# Altium schematic sheet SoM.SchDoc(SoM)
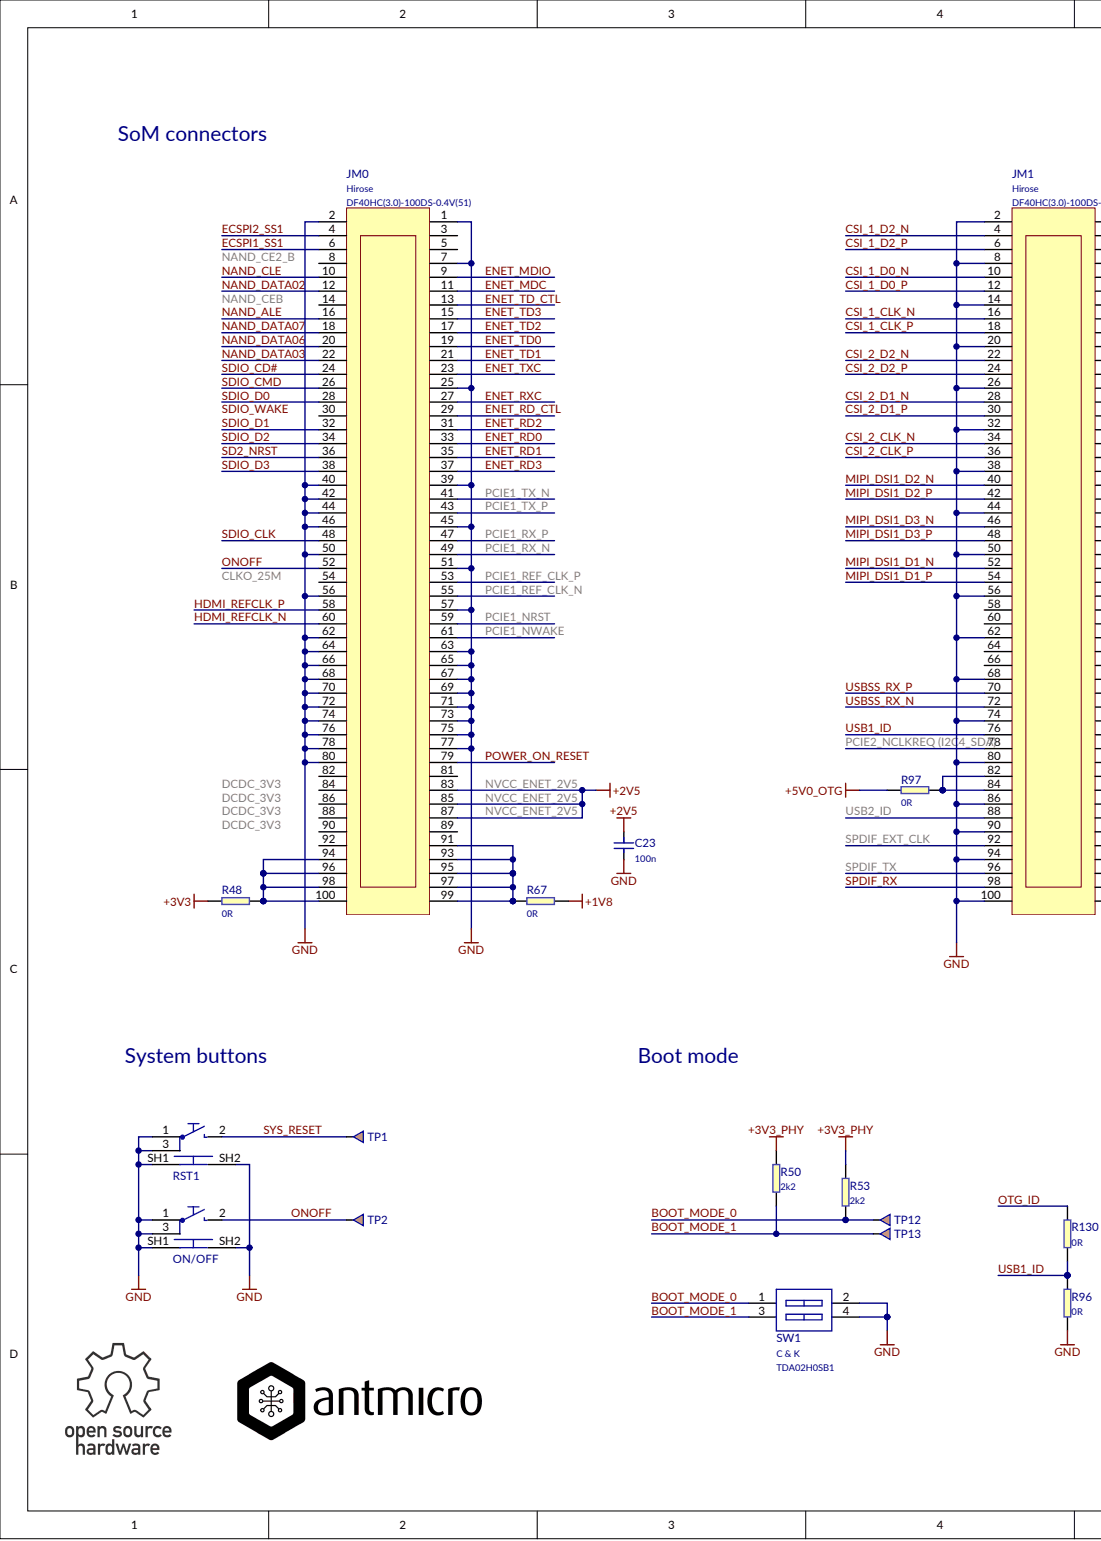
[diagram p0: SoM.SchDoc, left]
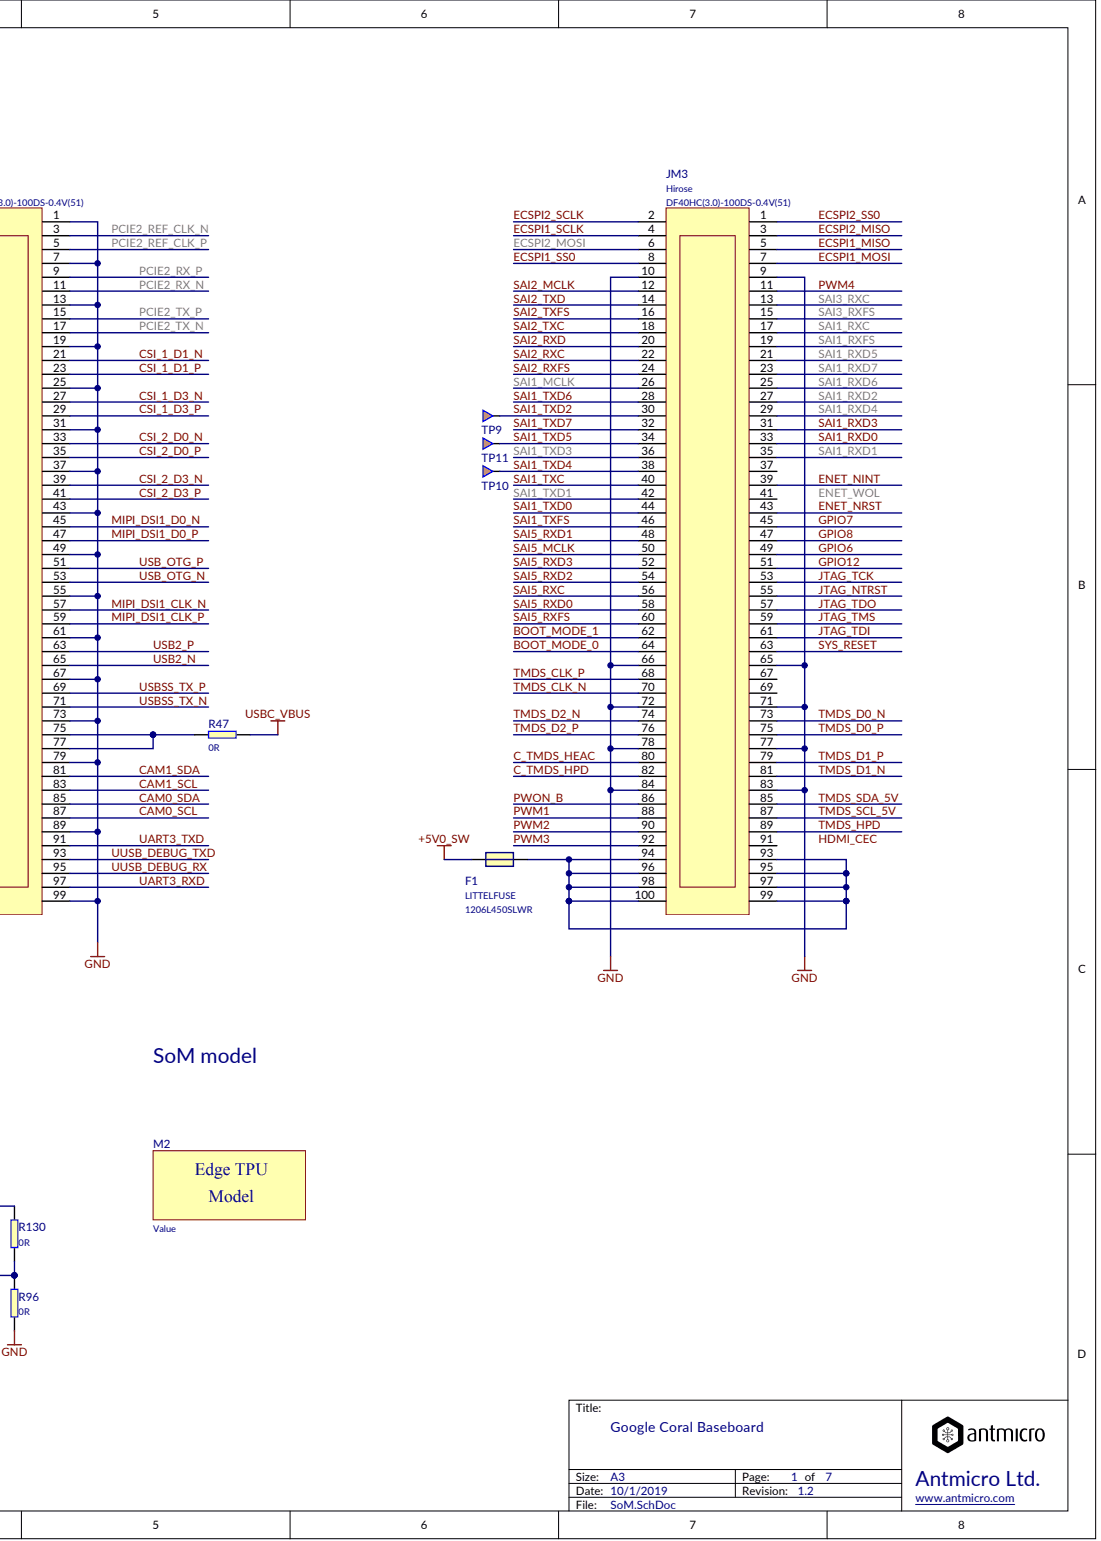
[diagram p1: SoM.SchDoc, right]

## Parts
C23  100n
F1  value 1206L450SLWR  Manufacturer: LITTELFUSE  (hidden comment: Value)
JM0  Manufacturer: Hirose  (hidden comment: DF40HC(3.0)-100DS-0.4V(51))
JM1  Manufacturer: Hirose  (hidden comment: DF40HC(3.0)-100DS-0.4V(51))
JM3  Manufacturer: Hirose  (hidden comment: DF40HC(3.0)-100DS-0.4V(51))
M2  =Value
ON/OFF  TL3340AF160QG  (value not drawn)
R47  0R
R48  0R
R50  2k2
R53  2k2
R67  0R
R96  0R
R97  0R
R130  0R
RST1  TL3340AF160QG  (value not drawn)
SW1  Manufacturer: C & K  (hidden comment: TDA02H0SB1)
TP1  Testpad  (value not drawn)
TP2  Testpad  (value not drawn)
TP9  Testpad  (value not drawn)
TP10  Testpad  (value not drawn)
TP11  Testpad  (value not drawn)
TP12  Testpad  (value not drawn)
TP13  Testpad  (value not drawn)

## Nets
+1V8: R67.2
+3V3: R48.2
+3V3_PHY: R50.2 R53.2
+5V0_OTG: R97.2
+5V0_SW: F1.1
GND: C23.2 JM0.1 JM0.2 JM0.7 JM0.25 JM0.39 JM0.40 JM0.42 JM0.44 JM0.45 JM0.46 JM0.50 JM0.51 JM0.56 JM0.57 JM0.62 JM0.63 JM0.64 JM0.65 JM0.66 JM0.67 JM0.68 JM0.69 JM0.70 JM0.71 JM0.72 JM0.73 JM0.74 JM0.75 JM0.76 JM0.77 JM0.78 JM0.80 JM1.1 JM1.2 JM1.7 JM1.8 JM1.13 JM1.14 JM1.19 JM1.20 JM1.25 JM1.26 JM1.31 JM1.32 JM1.37 JM1.38 JM1.43 JM1.44 JM1.49 JM1.50 JM1.55 JM1.56 JM1.61 JM1.62 JM1.67 JM1.68 JM1.73 JM1.74 JM1.79 JM1.80 JM1.86 JM1.89 JM1.90 JM1.94 JM1.99 JM1.100 JM3.9 JM3.10 JM3.65 JM3.66 JM3.71 JM3.72 JM3.77 JM3.78 JM3.83 JM3.84 ON/OFF.1 ON/OFF.3 ON/OFF.SH1 ON/OFF.SH2 R96.1 RST1.1 RST1.3 RST1.SH1 RST1.SH2 SW1.2 SW1.4
USBC_VBUS: R47.1
BOOT_MODE_0: JM3.64 R53.1 SW1.1 TP12.1
BOOT_MODE_1: JM3.62 R50.1 SW1.3 TP13.1
C_TMDS_HEAC: JM3.80
C_TMDS_HPD: JM3.82
CAM0_SCL: JM1.87
CAM0_SDA: JM1.85
CAM1_SCL: JM1.83
CAM1_SDA: JM1.81
CSI_1_CLK_N: JM1.16
CSI_1_CLK_P: JM1.18
CSI_1_D0_N: JM1.10
CSI_1_D0_P: JM1.12
CSI_1_D1_N: JM1.21
CSI_1_D1_P: JM1.23
CSI_1_D2_N: JM1.4
CSI_1_D2_P: JM1.6
CSI_1_D3_N: JM1.27
CSI_1_D3_P: JM1.29
CSI_2_CLK_N: JM1.34
CSI_2_CLK_P: JM1.36
CSI_2_D0_N: JM1.33
CSI_2_D0_P: JM1.35
CSI_2_D1_N: JM1.28
CSI_2_D1_P: JM1.30
CSI_2_D2_N: JM1.22
CSI_2_D2_P: JM1.24
CSI_2_D3_N: JM1.39
CSI_2_D3_P: JM1.41
ECSPI1_MISO: JM3.5
ECSPI1_MOSI: JM3.7
ECSPI1_SCLK: JM3.4
ECSPI1_SS0: JM3.8
ECSPI1_SS1: JM0.6
ECSPI2_MISO: JM3.3
ECSPI2_MOSI: JM3.6
ECSPI2_SCLK: JM3.2
ECSPI2_SS0: JM3.1
ECSPI2_SS1: JM0.4
ENET_MDC: JM0.11
ENET_MDIO: JM0.9
ENET_NINT: JM3.39
ENET_NRST: JM3.43
ENET_RD0: JM0.33
ENET_RD1: JM0.35
ENET_RD2: JM0.31
ENET_RD3: JM0.37
ENET_RD_CTL: JM0.29
ENET_RXC: JM0.27
ENET_TD0: JM0.19
ENET_TD1: JM0.21
ENET_TD2: JM0.17
ENET_TD3: JM0.15
ENET_TD_CTL: JM0.13
ENET_TXC: JM0.23
GPIO6: JM3.49
GPIO7: JM3.45
GPIO8: JM3.47
GPIO12: JM3.51
HDMI_REFCLK_N: JM0.60
HDMI_REFCLK_P: JM0.58
JTAG_NTRST: JM3.55
JTAG_TCK: JM3.53
JTAG_TDI: JM3.61
JTAG_TDO: JM3.57
JTAG_TMS: JM3.59
MIPI_DSI1_CLK_N: JM1.57
MIPI_DSI1_CLK_P: JM1.59
MIPI_DSI1_D0_N: JM1.45
MIPI_DSI1_D0_P: JM1.47
MIPI_DSI1_D1_N: JM1.52
MIPI_DSI1_D1_P: JM1.54
MIPI_DSI1_D2_N: JM1.40
MIPI_DSI1_D2_P: JM1.42
MIPI_DSI1_D3_N: JM1.46
MIPI_DSI1_D3_P: JM1.48
NAND_ALE: JM0.16
NAND_CLE: JM0.10
NAND_DATA02: JM0.12
NAND_DATA03: JM0.22
NAND_DATA06: JM0.20
NAND_DATA07: JM0.18
NVCC_ENET_2V5: C23.1 JM0.83 JM0.85 JM0.87
ONOFF: JM0.52 ON/OFF.2 TP2.1
OTG_ID: R130.2
PCIE1_NRST: JM0.59
PCIE1_NWAKE: JM0.61
PCIE1_REF_CLK_N: JM0.55
PCIE1_REF_CLK_P: JM0.53
PCIE1_RX_N: JM0.49
PCIE1_RX_P: JM0.47
PCIE1_TX_N: JM0.41
PCIE1_TX_P: JM0.43
PCIE2_NCLKREQ (I2C4_SDA): JM1.78
PCIE2_REF_CLK_N: JM1.3
PCIE2_REF_CLK_P: JM1.5
PCIE2_RX_N: JM1.11
PCIE2_RX_P: JM1.9
PCIE2_TX_N: JM1.17
PCIE2_TX_P: JM1.15
POWER_ON_RESET: JM0.79
PWM1: JM3.88
PWM2: JM3.90
PWM3: JM3.92
PWM4: JM3.11
PWON_B: JM3.86
SAI1_MCLK: JM3.26
SAI1_RXC: JM3.17
SAI1_RXD0: JM3.33
SAI1_RXD1: JM3.35
SAI1_RXD2: JM3.27
SAI1_RXD3: JM3.31
SAI1_RXD4: JM3.29
SAI1_RXD5: JM3.21
SAI1_RXD6: JM3.25
SAI1_RXD7: JM3.23
SAI1_RXFS: JM3.19
SAI1_TXC: JM3.40
SAI1_TXD0: JM3.44
SAI1_TXD1: JM3.42
SAI1_TXD2: JM3.30 TP9.1
SAI1_TXD3: JM3.36
SAI1_TXD4: JM3.38 TP10.1
SAI1_TXD5: JM3.34 TP11.1
SAI1_TXD6: JM3.28
SAI1_TXD7: JM3.32
SAI1_TXFS: JM3.46
SAI2_MCLK: JM3.12
SAI2_RXC: JM3.22
SAI2_RXD: JM3.20
SAI2_RXFS: JM3.24
SAI2_TXC: JM3.18
SAI2_TXD: JM3.14
SAI2_TXFS: JM3.16
SAI3_RXC: JM3.13
SAI3_RXFS: JM3.15
SAI5_MCLK: JM3.50
SAI5_RXC: JM3.56
SAI5_RXD0: JM3.58
SAI5_RXD1: JM3.48
SAI5_RXD2: JM3.54
SAI5_RXD3: JM3.52
SAI5_RXFS: JM3.60
SD2_NRST: JM0.36
SDIO_CD#: JM0.24
SDIO_CLK: JM0.48
SDIO_CMD: JM0.26
SDIO_D0: JM0.28
SDIO_D1: JM0.32
SDIO_D2: JM0.34
SDIO_D3: JM0.38
SPDIF_EXT_CLK: JM1.92
SPDIF_RX: JM1.98
SPDIF_TX: JM1.96
SYS_RESET: JM3.63 RST1.2 TP1.1
TMDS_CLK_N: JM3.70
TMDS_CLK_P: JM3.68
TMDS_D0_N: JM3.73
TMDS_D0_P: JM3.75
TMDS_D1_N: JM3.81
TMDS_D1_P: JM3.79
TMDS_D2_N: JM3.74
TMDS_D2_P: JM3.76
TMDS_HPD: JM3.89
TMDS_SCL_5V: JM3.87
TMDS_SDA_5V: JM3.85
UART3_RXD: JM1.97
UART3_TXD: JM1.91
USB1_ID: JM1.76 R96.2 R130.1
USB2_ID: JM1.88
USB2_N: JM1.65
USB2_P: JM1.63
USB_OTG_N: JM1.53
USB_OTG_P: JM1.51
USBSS_RX_N: JM1.72
USBSS_RX_P: JM1.70
USBSS_TX_N: JM1.71
USBSS_TX_P: JM1.69
UUSB_DEBUG_RX: JM1.95
UUSB_DEBUG_TXD: JM1.93
NetF1_2: F1.2 JM3.93 JM3.94 JM3.95 JM3.96 JM3.97 JM3.98 JM3.99 JM3.100
NetJM0_91: JM0.91 JM0.93 JM0.95 JM0.97 JM0.99 R67.1
NetJM0_94: JM0.94 JM0.96 JM0.98 JM0.100 R48.1
NetJM1_75: JM1.75 JM1.77 R47.2
NetJM1_82: JM1.82 JM1.84 R97.1
CLKO_25M:
DCDC_3V3:
ENET_WOL:
HDMI_CEC:
NAND_CE2_B:
NAND_CEB:
SDIO_WAKE:

Not connected: JM0 3 5 8 14 30 54 81 82 84 86 88 89 90 92; JM1 58 60 64 66; JM3 37 41 67 69 91

## Library data of the parts
C23 = (no symbol name): PartNumber: C_100n_0402; Value: 100n
M2 = (no symbol name): PartNumber: Edge-TPU-SOM-model
ON/OFF RST1 = (no symbol name): Manufacturer: E-SWITCH; PartNumber: TL3340AF160QG; Value: TL3340AF160QG
R47 R96 R97 R130 = (no symbol name): PartNumber: R_0R_0402; Value: 0R
R48 R67 = (no symbol name): PartNumber: R_0R_0805; Value: 0R
R50 R53 = (no symbol name): PartNumber: R_2k2_0402; Value: 2k2
F1 JM0 JM1 JM3 SW1 TP1 TP2 TP9-TP13 = (no symbol name)
  F1: PartNumber: 1206L450SLWR
  JM0 JM1 JM3: PartNumber: DF40HC(3.0)-100DS-0.4V(51); Value: DF40HC(3.0)-100DS-0.4V(51)
  SW1: Value: TDA02H0SB1
  TP1 TP2 TP9-TP13: PartNumber: Testpad
